# Altium schematic sheet 5_Auto_Reset.SchDoc
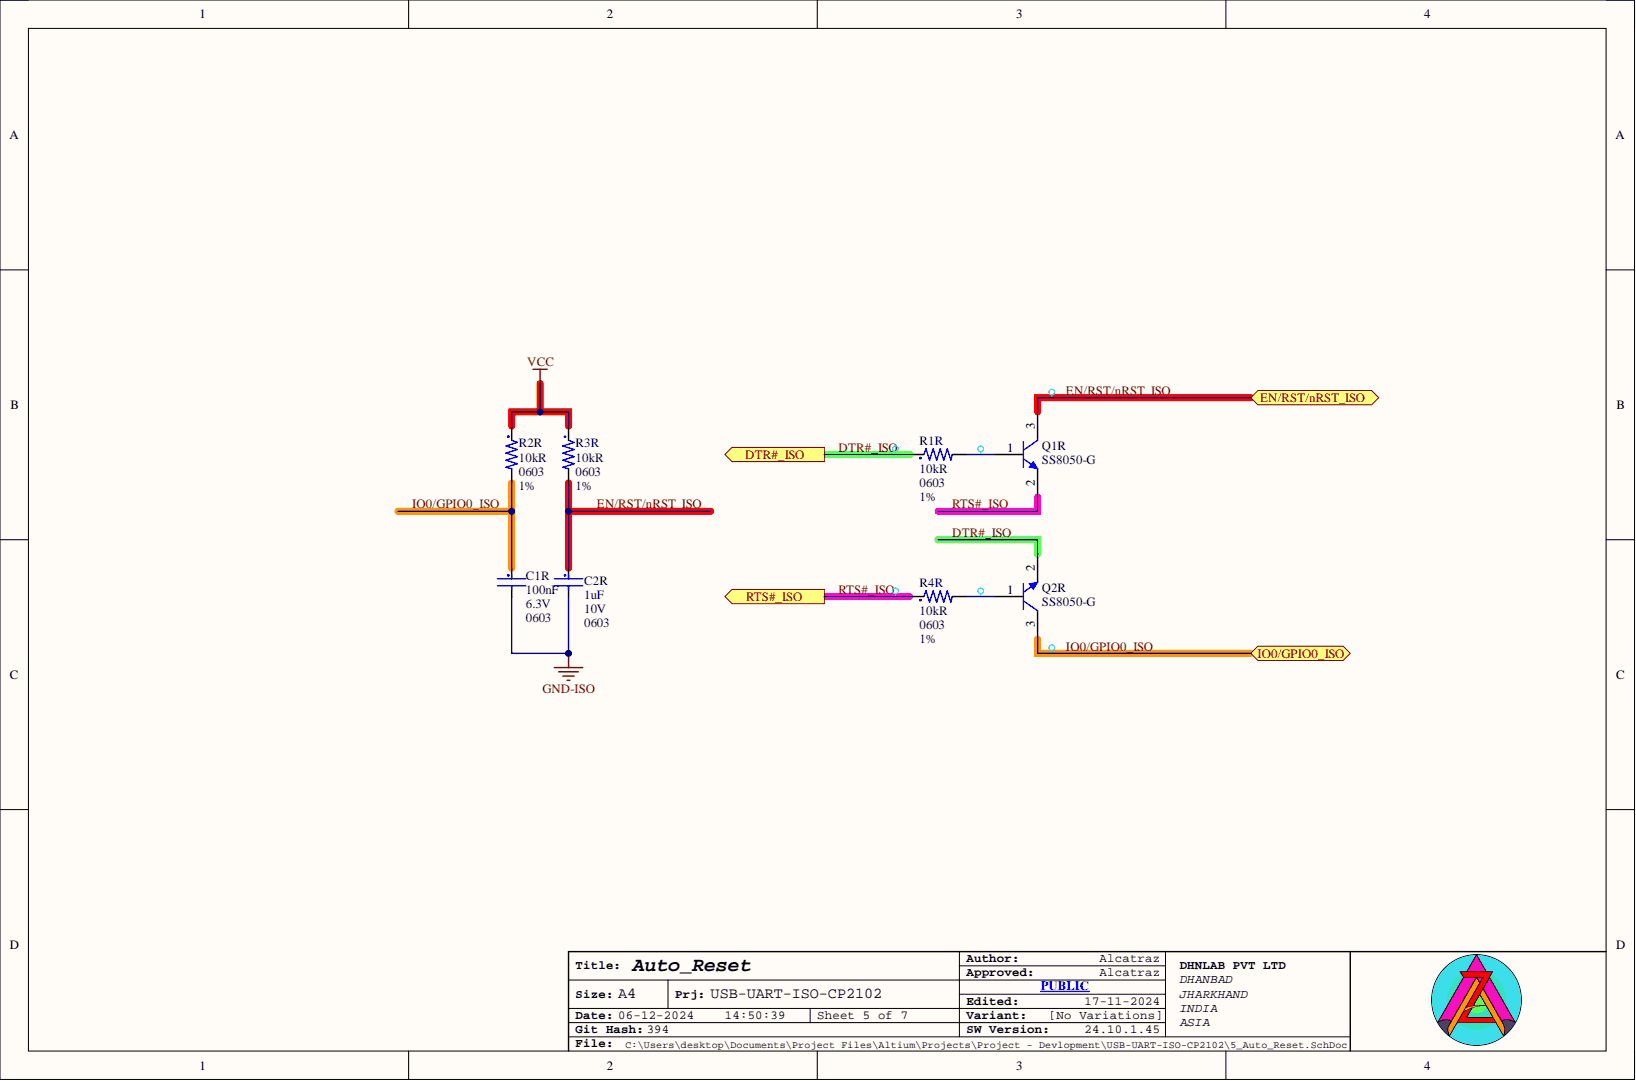
[diagram p0: 5_Auto_Reset.SchDoc]

## Parts
C1R  value 100nF  Package: 0603  Voltage: 6.3V  [C0603-IPC_C_No_Silk]  (hidden comment: KGM15AR70J104KM)
C2R  value 1uF  Case Code (Imperial): 0603  Voltage: 10V  [C0603-IPC_C_No_Silk]  (hidden comment: C0603C105K8PACTU)
Q1R  SS8050-G  [SOT-23-3-MFG]
Q2R  SS8050-G  [SOT-23-3-MFG]
R1R  value 10kR  Case Code (Imperial): 0603  [R0603-MFG]  (hidden comment: RC0603FR-0710KL)
R2R  value 10kR  Case Code (Imperial): 0603  Resistance Tolerance: 1%  [R0603-MFG]  (hidden comment: RC0603FR-0710KL)
R3R  value 10kR  Case Code (Imperial): 0603  Resistance Tolerance: 1%  [R0603-MFG]  (hidden comment: RC0603FR-0710KL)
R4R  value 10kR  Case Code (Imperial): 0603  [R0603-MFG]  (hidden comment: RC0603FR-0710KL)

## Ports
DTR#_ISO, EN/RST/nRST_ISO, IO0/GPIO0_ISO, RTS#_ISO

## Nets
GND-ISO: C1R.2 C2R.2
VCC: R2R.1 R3R.1
DTR#_ISO: Q2R.2 R1R.1 ; port DTR#_ISO
EN/RST/nRST_ISO: C2R.1 Q1R.3 R3R.2 ; port EN/RST/nRST_ISO
IO0/GPIO0_ISO: C1R.1 Q2R.3 R2R.2 ; port IO0/GPIO0_ISO
RTS#_ISO: Q1R.2 R4R.1 ; port RTS#_ISO
NetQ1R_1: Q1R.1 R1R.2
NetQ2R_1: Q2R.1 R4R.2

## Library data of the parts
C1R = 100nF_6.3V: Cap Cer 0.1UF 6.3V X7R 0603; Manufacturer: KYOCERA AVX; Tol: 10%; Category: Ceramic Capacitors; Component Kind: Standard; Library Name: Lib_Capacitor_0603.IntLib; Manufacturer Part Number: KGM15AR70J104KM; Pricing 1: 1=0.1, 10=0.032, 50=0.0186, 100=0.0144, 500=0.01082, 1000=0.0085, 2500=0.00772, 5000=0.00711 (USD); Stock 1: 117167; Supplier 1: Digikey; Supplier Part Number 1: 478-KGM15AR70J104KMCT-ND
C2R = 1uF_10V: Ceramic Capacitor, Multilayer, Ceramic, 10V, 10% +Tol, 10% -Tol, X5R, 15% TC, 1uF, 0603; Manufacturer: KEMET; Case Code (Metric): 1608; Case/Package: 0603; Category: Ceramic Capacitors; Component Kind: Standard; Construction: Flat; Depth: 0.8mm; Dielectric: X5R; Dielectric Material: Ceramic; Height: 0.8mm; Lead Free: 5697LeadFree; Length: 1.6mm; Library Name: Lib_Capacitor_0603.IntLib; Manufacturer Part Number: C0603C105K8PACTU; Max Operating Temperature: 85°C; Min Operating Temperature: -55°C; Mounting Technology: Surface Mount; Package Quantity: 1; Packaging: Tape and Reel; Pins: 2; Pricing 1: 1=0.1, 10=0.03, 50=0.03, 100=0.018, 1000=0.014, 10000=0.009 (USD); Radiation Hardening: No; Reach SVHC Compliant: No; RoHS: Yes; RoHS Compliant: Yes; Series: C; Stock 1: 221942; Supplier 1: Mouser; Termination: SMD/SMT; Thickness: 0.035inch; Tolerance: 10%; Voltage Rating: 10V; Voltage Rating (DC): 10V; Weight: 7.1E-05oz; Width: 0.031inch
Q1R Q2R = SS8050-G: Trans Gp Bjt NPN 25V 1.5A 300MW 3-PIN SOT-23 T/r; Manufacturer: Comchip; Collector Base Voltage (VCBO): 40V; Collector Emitter Saturation Voltage: 500mV; Collector-emitter Voltage-Max: 400mV; Emitter Base Voltage (VEBO): 5V; hFE Min: 200NUMBER; Number of Elements: 1NUMBER; Transition Frequency: 100MHz; Case/Package: TO-236-3; Category: Transistors; Collector Emitter Breakdown Voltage: 25V; Component Kind: Standard; ComponentLink1Description: http://www.comchiptech.com/admin/files/product/SS8050-G RevA181526.pdf?src-supplier=Digikey; ComponentLink1URL: http://www.comchiptech.com/admin/files/product/SS8050-G RevA181526.pdf?src-supplier=Digikey; Height: 1.15mm; Library Name: Lib_Transistor_NPN.IntLib; Manufacturer Part Number: SS8050-G; Max Breakdown Voltage: 25V; Max Collector Current: 1.5A; Max Operating Temperature: 150°C; Max Power Dissipation: 300mW; Mounting Technology: Surface Mount; Packaging: Cut Tape; Power Dissipation: 300mW; Pricing 1: 2854=0.0684 (USD); RoHS: Yes; RoHS Compliant: Yes; Series: -; Stock 1: 2854; Supplier 1: Verical; Supplier Part Number 1: SS8050-G
R1R R2R R3R R4R = 10K: SMD Chip Resistor, 10 kOhm, ± 1%, 100 mW, 0603 [1608 Metric], Thick Film, General Purpose; Manufacturer: Yageo; Max Power Dissipation: 100mW; Temperature Coefficient: 100ppm/°C; Voltage Rating: 75V; Voltage Rating (DC): 50V; Case Code (Metric): 1608; Case/Package: 0603; Category: Chip SMD Resistors; Component Kind: Standard; Construction: Rectangular; Depth: 0.8mm; Features: Moisture Resistant; Height: 0.45mm; Lead Free: 5697LeadFree; Lead Pitch: 0.3mm; Length: 1.6mm; Library Name: Lib_Register_0603.IntLib; Manufacturer Part Number: RC0603FR-0710KL; Max Operating Temperature: 155°C; Military Standard: Not; Min Operating Temperature: -55°C; Number of Terminations: 2; Packaging: Tape and Reel; Pins: 2; Power Rating: 100mW; Pricing 1: 5000=0.0015, 50000=0.0014, 150000=0.0014, 375000=0.0013, 1000000=0.0012 (USD); Reach SVHC Compliant: No; Resistor Type: Thick Film; RoHS: Yes; RoHS Compliant: Yes; Series: RC; Stock 1: 44660000; Supplier 1: Future Electronics; Supplier Part Number 1: 4023214; Termination: SMD/SMT; Tolerance: 1%; Weight: 7.1E-05oz; Width: 0.8mm
  R1R R4R: Resistance Tolerance: 1%
